# Altium schematic sheet DriverAndProtection.SchDoc
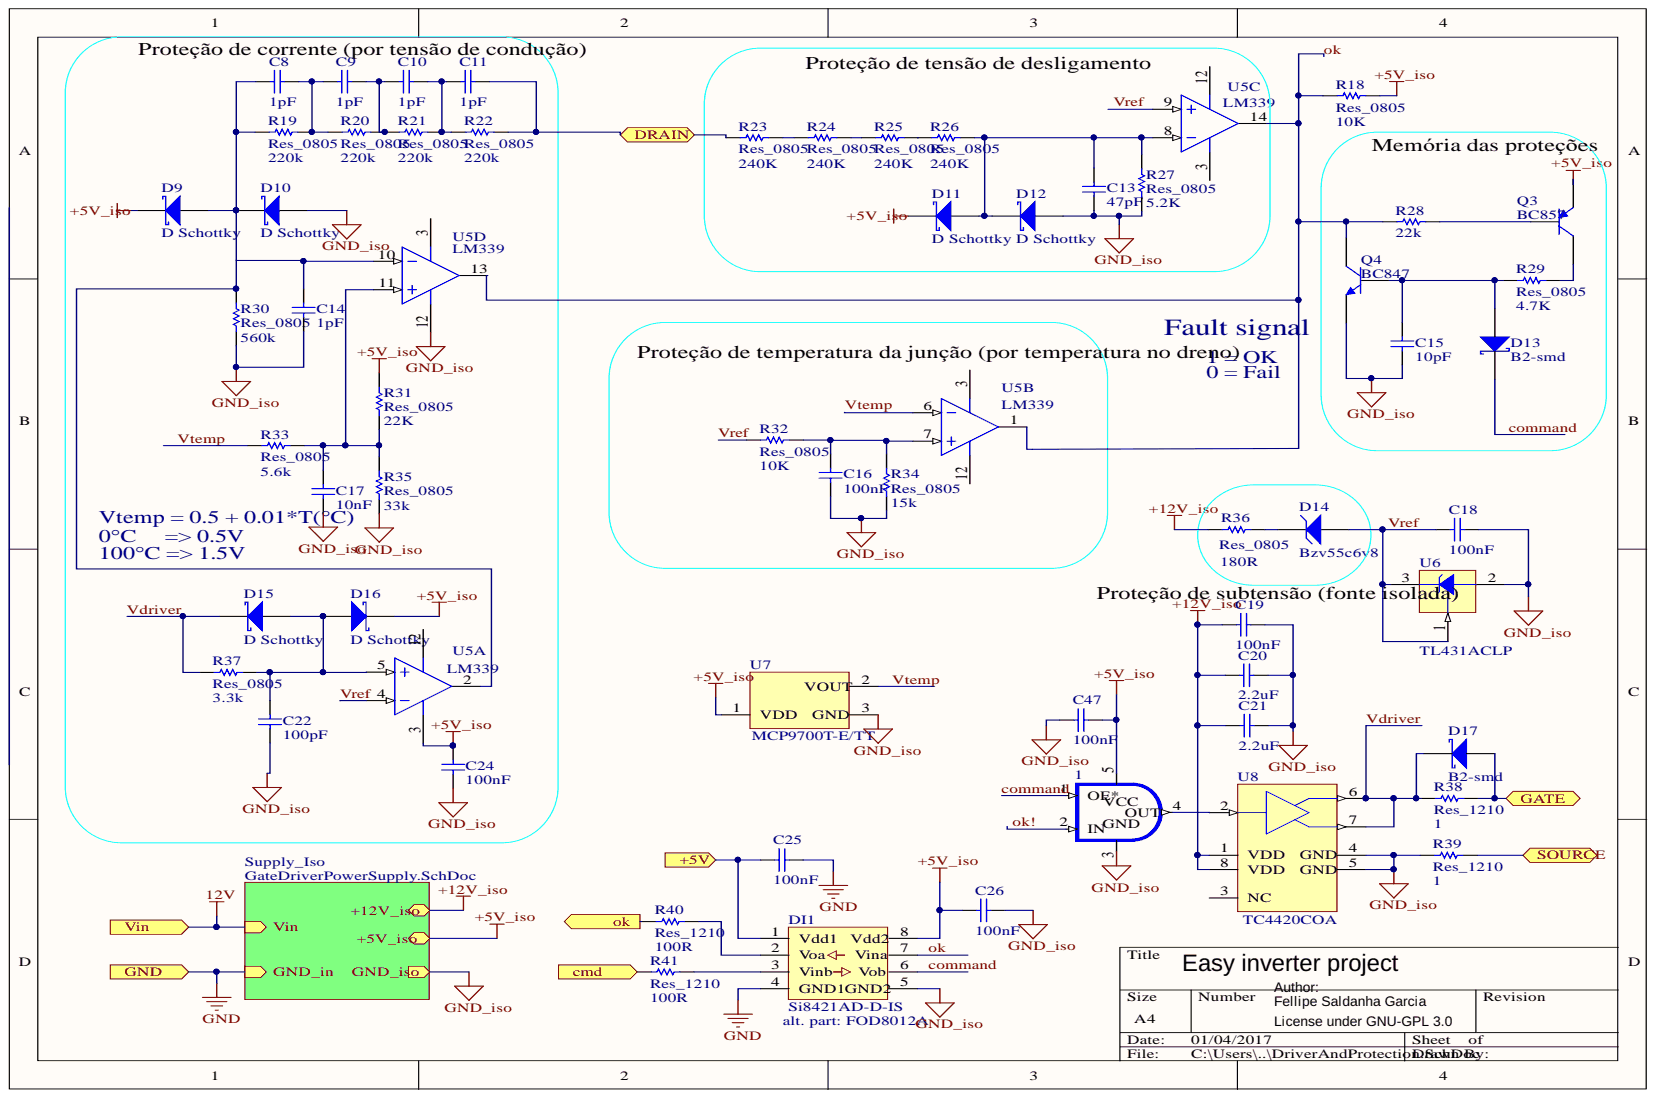
[diagram p0: DriverAndProtection.SchDoc]

## Parts
1  (no value)  [SOT353]
C8  value 1pF  [0805_W]  (hidden comment: Cap_0805)
C9  value 1pF  [0805_W]  (hidden comment: Cap_0805)
C10  value 1pF  [0805_W]  (hidden comment: Cap_0805)
C11  value 1pF  [0805_W]  (hidden comment: Cap_0805)
C13  value 47pF  [0805_W]  (hidden comment: Cap_0805)
C14  value 1pF  [0805_W]  (hidden comment: Cap_0805)
C15  value 10pF  [0805_W]  (hidden comment: Cap_0805)
C16  value 100nF  [0805_W]  (hidden comment: Cap_0805)
C17  value 10nF  [0805_W]  (hidden comment: Cap_0805)
C18  value 100nF  [0805_W]  (hidden comment: Cap_0805)
C19  value 100nF  [0805_W]  (hidden comment: Cap_0805)
C20  value 2.2uF  [0805_W]  (hidden comment: Capacitor_nao_polarizado)
C21  value 2.2uF  [0805_W]  (hidden comment: Capacitor_nao_polarizado)
C22  value 100pF  [0805_W]  (hidden comment: Cap_0805)
C24  value 100nF  [0805_W]  (hidden comment: Cap_0805)
C25  value 100nF  [0805_W]  (hidden comment: Cap_0805)
C26  value 100nF  [0805_W]  (hidden comment: Cap_0805)
C47  value 100nF  [0805_W]  (hidden comment: Capacitor_nao_polarizado)
D9  D Schottky  [SOD-123]
D10  D Schottky  [SOD-123]
D11  D Schottky  [SOD-123]
D12  D Schottky  [SOD-123]
D13  B2-smd  [SOD-123]
D14  Bzv55c6v8  [SOD-123]
D15  D Schottky  [SOD-123]
D16  D Schottky  [SOD-123]
D17  B2-smd  [SOD-123]
DI1  Si8421AD-D-IS  [8SOIC]
Q3  BC857  [SOT-23-TT3_N]
Q4  BC847  [SOT-23-TT3_N]
R18  Res_0805  value 10K  [0805_W]
R19  Res_0805  value 220k  [0805_W]
R20  Res_0805  value 220k  [0805_W]
R21  Res_0805  value 220k  [0805_W]
R22  Res_0805  value 220k  [0805_W]
R23  Res_0805  value 240K  [0805_W]
R24  Res_0805  value 240K  [0805_W]
R25  Res_0805  value 240K  [0805_W]
R26  Res_0805  value 240K  [0805_W]
R27  Res_0805  value 5.2K  [0805_W]
R28  value 22k  [0805_W]  (hidden comment: Res_0805)
R29  Res_0805  value 4.7K  [0805_W]
R30  Res_0805  value 560k  [0805_W]
R31  Res_0805  value 22K  [0805_W]
R32  Res_0805  value 10K  [0805_W]
R33  Res_0805  value 5.6k  [0805_W]
R34  Res_0805  value 15k  [0805_W]
R35  Res_0805  value 33k  [0805_W]
R36  Res_0805  value 180R  [0805_W]
R37  Res_0805  value 3.3k  [0805_W]
R38  Res_1210  [1210_W]
R39  Res_1210  value 1  [1210_W]
R40  Res_1210  value 100R  [0805_W]
R41  Res_1210  value 100R  [0805_W]
U5  LM339  [SOIC-14N]  (parts A,B,C,D)
U6  TL431ACLP  [SOT-3N]
U7  MCP9700T-E/TT  [SOT-23_L]
U8  TC4420COA  [SOIC-8N]

## Ports
+5V, CMD, DRAIN, GATE, GND, OK, SOURCE, VIN

## Nets
+5V_ISO: 1.5 C24.1 C26.2 C47.2 D9.2 D11.2 D16.2 DI1.8 Q3.2 R18.2 R31.2 U5.3 U7.1
+12V_ISO: C19.2 C20.1 C21.1 R36.2 U8.1 U8.8
GND_ISO: 1.3 C13.2 C14.2 C15.2 C16.2 C17.2 C18.2 C19.1 C20.2 C21.2 C22.2 C24.2 C26.1 C47.1 D10.1 D12.1 DI1.5 Q4.2 R27.1 R30.1 R34.2 R35.2 R39.2 U5.12 U6.2 U7.3 U8.4 U8.5
+5V: C25.2 DI1.1 ; port +5V
COMMAND: 1.1 D13.2 DI1.6
DRAIN: C11.1 R22.2 R23.2 ; port DRAIN
GATE: D17.1 R38.1 ; port GATE
GND: C25.1 DI1.4 ; port GND
OK: DI1.7 Q4.3 R18.1 R28.2 U5.1 U5.13 U5.14
OK!: 1.2
SOURCE: R39.1 ; port SOURCE
VDRIVER: D15.2 D17.2 R37.2 R38.2 U8.6 U8.7
VREF: C18.1 D14.1 R32.2 U5.4 U5.9 U6.1 U6.3
VTEMP: R33.2 U5.6 U7.2
Net1_4: 1.4 U8.2
NetC8_1: C8.1 C9.2 R19.2 R20.2
NetC8_2: C8.2 C14.1 D9.1 D10.2 R19.1 R30.2 U5.2 U5.10
NetC9_1: C9.1 C10.2 R20.1 R21.2
NetC10_1: C10.1 C11.2 R21.1 R22.1
NetC13_1: C13.1 D11.1 D12.2 R26.1 R27.2 U5.8
NetC15_1: C15.1 D13.1 Q4.1 R29.2
NetC16_1: C16.1 R32.1 R34.1 U5.7
NetC17_1: C17.1 R31.1 R33.1 R35.1 U5.11
NetC22_1: C22.1 D15.1 D16.1 R37.1 U5.5
NetD14_2: D14.2 R36.1
NetDI1_2: DI1.2 R40.1
NetDI1_3: DI1.3 R41.1
NetQ3_1: Q3.1 R28.1
NetQ3_3: Q3.3 R29.1
NetR23_1: R23.1 R24.2
NetR24_1: R24.1 R25.2
NetR25_1: R25.1 R26.2
NetR40_2: R40.2 ; port OK
NetR41_2: R41.2 ; port CMD
12V: ; port VIN
N00181:

Not connected: U8 3

## Library data of the parts
1 = AND: Component Kind: Standard; Library Name: Inversor_LCEE.SchLib
C8-C11 C13-C19 C22 C24-C26 = Cap_0805: Capacitor; Component Kind: Standard; LatestRevisionDate: 17-Jul-2002; LatestRevisionNote: Re-released for DXP Platform.; PackageReference: RAD-0.3; Published: 8-Jun-2000; Signal Integrity: Cap; Simulation: CAP; Library Name: Inversor_LCEE.SchLib; Publisher: Altium Limited
C20 C21 C47 = Capacitor_nao_polarizado: Capacitor nao polarizado; Component Kind: Standard; Library Name: Sch_LCEE.SchLib
D9-D12 D15 D16 = D Schottky: Schottky Diode; Code_JEDEC: DO-214-AA; Component Kind: Standard; LatestRevisionDate: 17-Jul-2002; LatestRevisionNote: Re-released for DXP Platform.; Library Name: Miscellaneous Devices.IntLib; PackageDescription: DO-214-AA/SMB; 2 C-Bend Leads; Body 5.3 x 3.6 mm, inc. leads (LxW); PackageReference: SMB; Published: 8-Jun-2000; Signal Integrity: D Schottky; Simulation: SKYDIODE; Publisher: Altium Limited
D13 D17 = Schottky: Diodo Schottky; Component Kind: Standard; Library Name: Sch_LCEE.SchLib
D14 = D Zener: Zener Diode; Component Kind: Standard; LatestRevisionDate: 17-Jul-2002; LatestRevisionNote: Re-released for DXP Platform.; Library Name: Miscellaneous Devices.IntLib; PackageDescription: Diode, Thru-Hole; 2 Leads; 0.7 in Pin Spacing; PackageReference: DIODE-0.7; Published: 8-Jun-2000; Signal Integrity: D Zener; Simulation: ZENER; Publisher: Altium Limited
DI1 = FOD8012: Two Channel, High Speed Digital Isolators; Component Kind: Standard; Library Name: Inversor_LCEE.SchLib
Q3 = BC857: PNP General-purpose Transistor; Component Kind: Standard; ComponentLink1Description: Manufacturer Link; ComponentLink1URL: http://www.semiconductors.philips.com/; ComponentLink2Description: Datasheet; ComponentLink2URL: http://www.semiconductors.philips.com/acrobat/datasheets/BC856_BC857_BC858_6.pdf; DatasheetVersion: 4-Feb-2002; LatestRevisionDate: 12-Dec-2006; LatestRevisionNote: IPC-7351 Footprint Added.; Library Name: Philips Discrete BJT - Low Power.IntLib; PackageDescription: 3-Pin SOT-23 Package 0.95 mm Pitch, 2.3 mm Lead Span; PackageReference: SOT23; PackageVersion: May-1999; Published: 17-Sep-2003; Signal Integrity: BC857; Simulation: QBC857; Publisher: Altium Limited
Q4 = BC847: NPN General-purpose Transistor; Component Kind: Standard; ComponentLink1Description: Manufacturer Link; ComponentLink1URL: http://www.semiconductors.philips.com/; ComponentLink2Description: Datasheet; ComponentLink2URL: http://www.semiconductors.philips.com/acrobat/datasheets/BC846_BC847_BC848_5.pdf; DatasheetVersion: 4-Feb-2002; LatestRevisionDate: 12-Dec-2006; LatestRevisionNote: IPC-7351 Footprint Added.; Library Name: Philips Discrete BJT - Low Power.IntLib; PackageDescription: 3-Pin SOT-23 Package 0.95 mm Pitch, 2.3 mm Lead Span; PackageReference: SOT23; PackageVersion: May-1999; Published: 17-Sep-2003; Signal Integrity: BC847; Simulation: QBC847; Publisher: Altium Limited
R18-R28 R30-R37 R39 R41 = Res_0805: Resistor; Manufacturer: Generic Components; Class: Passive; Component Kind: Standard; Published: 08/06/2000; Signal Integrity: Res1; Simulation: RESISTOR; SubClass: Resistor; Library Name: Inversor_LCEE.SchLib; Publisher: Altium Hobart Technology Centre
R29 = Res_0805: Resistor; Manufacturer: Generic Components; Class: Passive; Value: 4.7K; Component Kind: Standard; Published: 08/06/2000; Signal Integrity: Res1; Simulation: RESISTOR; SubClass: Resistor; Library Name: Inversor_LCEE.SchLib; Publisher: Altium Hobart Technology Centre
R38 = Res_0805: Resistor; Manufacturer: Generic Components; Class: Passive; Value: 1; Component Kind: Standard; Published: 08/06/2000; Signal Integrity: Res1; Simulation: RESISTOR; SubClass: Resistor; Library Name: Inversor_LCEE.SchLib; Publisher: Altium Hobart Technology Centre
R40 = Res_0805: Resistor; Manufacturer: Generic Components; Class: Passive; Value: 100R; Component Kind: Standard; Published: 08/06/2000; Signal Integrity: Res1; Simulation: RESISTOR; SubClass: Resistor; Library Name: Inversor_LCEE.SchLib; Publisher: Altium Hobart Technology Centre
U5 = LM339AD: Low-Power Quad Voltage Comparator; Code_IPC: SOIC127P600-14; Component Kind: Standard; ComponentLink1Description: Manufacturer Link; ComponentLink1URL: http://www.st.com/; ComponentLink2Description: Datasheet; ComponentLink2URL: http://www.st.com/stonline/products/literature/ds/2159/lm239.pdf; DatasheetVersion: Jun-1998; LatestRevisionDate: 13-Apr-2006; LatestRevisionNote: IPC-7351 Footprint Added.; Library Name: ST Analog Comparator.IntLib; PackageDescription: 14-Pin Small Outline Integrated Circuit 1.27 mm Pitch; PackageReference: SO14; PackageVersion: Sep-1998; PCB3D: SO14; Published: 24-Aug-2001; Publisher: Altium Limited
U6 = TL431ACLP: Programmable Precision Reference; Component Kind: Standard; ComponentLink1Description: Manufacturer Link; ComponentLink1URL: http://www.onsemi.com/site/products/taxonomy/0,4438,503,00.html; ComponentLink2Description: Datasheet; ComponentLink2URL: http://www.onsemi.com/pub/Collateral/TL431-D.PDF; DatasheetVersion: 1999; Library Name: ON Semi Power Mgt Voltage Reference.IntLib; PackageDescription: TO, Flat Index; 3 In-Line, Axial Leads; Body Dia. 4.8mm; Leads 0.48 x 0.51 mm (max); PackageReference: 29-04; PackageVersion: 1996; Published: 15-Apr-2002; Publisher: Altium Limited
U7 = MCP9700T-E/TT: Low-Power Linear Active Thermistor IC, 3-Pin SOT-23, Extended Temperature, Tape and Reel; Code_IPC: SOT23-3; Component Kind: Standard; ComponentLink1Description: Datasheet; ComponentLink1URL: http://ww1.microchip.com/downloads/en/DeviceDoc/21942e.pdf; ComponentLink2Description: Packaging Specification; ComponentLink2URL: http://www.microchip.com/stellent/groups/techpub_sg/documents/packagingspec/en012702.pdf; ComponentLink3Description: Microchip.com Home; ComponentLink3URL: http://www.microchip.com/; DatasheetVersion: revE, Apr-2009; LatestRevisionDate: 02-Dec-2009; LatestRevisionNote: Updated datasheet to version revE, Apr-2009.; Library Name: Microchip Thermal Management.IntLib; PackageDescription: 3-Lead Plastic Small Outline Transistor (TT) [SOT-23]; PackageReference: SOT-23-TT3; PackageVersion: revBB, Aug-2009; Published: 29-Jan-2009; Supplier 1: Digi-Key; Supplier 2: Digi-Key; Supplier 3: Digi-Key; Supplier 4: Farnell; Supplier Part Number 1: MCP9700T-E/TTTR-ND; Supplier Part Number 2: MCP9700T-E/TTCT-ND; Supplier Part Number 3: MCP9700T-E/TTDKR-ND; Supplier Part Number 4: 1627188; Publisher: Altium Limited
U8 = TC4420COA: 6A High-Speed MOSFET Driver, Non-Inverting, Commercial Temperature; Code_IPC: SOIC127P600-8; Component Kind: Standard; ComponentLink1Description: Datasheet; ComponentLink1URL: http://ww1.microchip.com/downloads/en/DeviceDoc/21419c.pdf; ComponentLink2Description: Packaging Specification; ComponentLink2URL: http://www.microchip.com/stellent/groups/techpub_sg/documents/packagingspec/en012702.pdf; ComponentLink3Description: Microchip.com Home; ComponentLink3URL: http://www.microchip.com/; DatasheetVersion: revC, Aug-2004; LatestRevisionDate: 01-Mar-2010; LatestRevisionNote: Sch Layout changed.; Library Name: Microchip Power Mgt MOSFET Driver.IntLib; PackageDescription: 8-Lead Plastic Small Outline (OA) - Narrow, 3.90mm Body [SOIC]; PackageReference: SOIC-OA8; PackageVersion: revBB, Aug-2009; Published: 29-Jan-2009; Simulation: TC4420_I2D_B; Supplier 1: Digi-Key; Supplier 2: Farnell; Supplier Part Number 1: TC4420COA-ND; Supplier Part Number 2: 1292283; Publisher: Altium Limited
